# Altium schematic sheet Security panel power.SchDoc
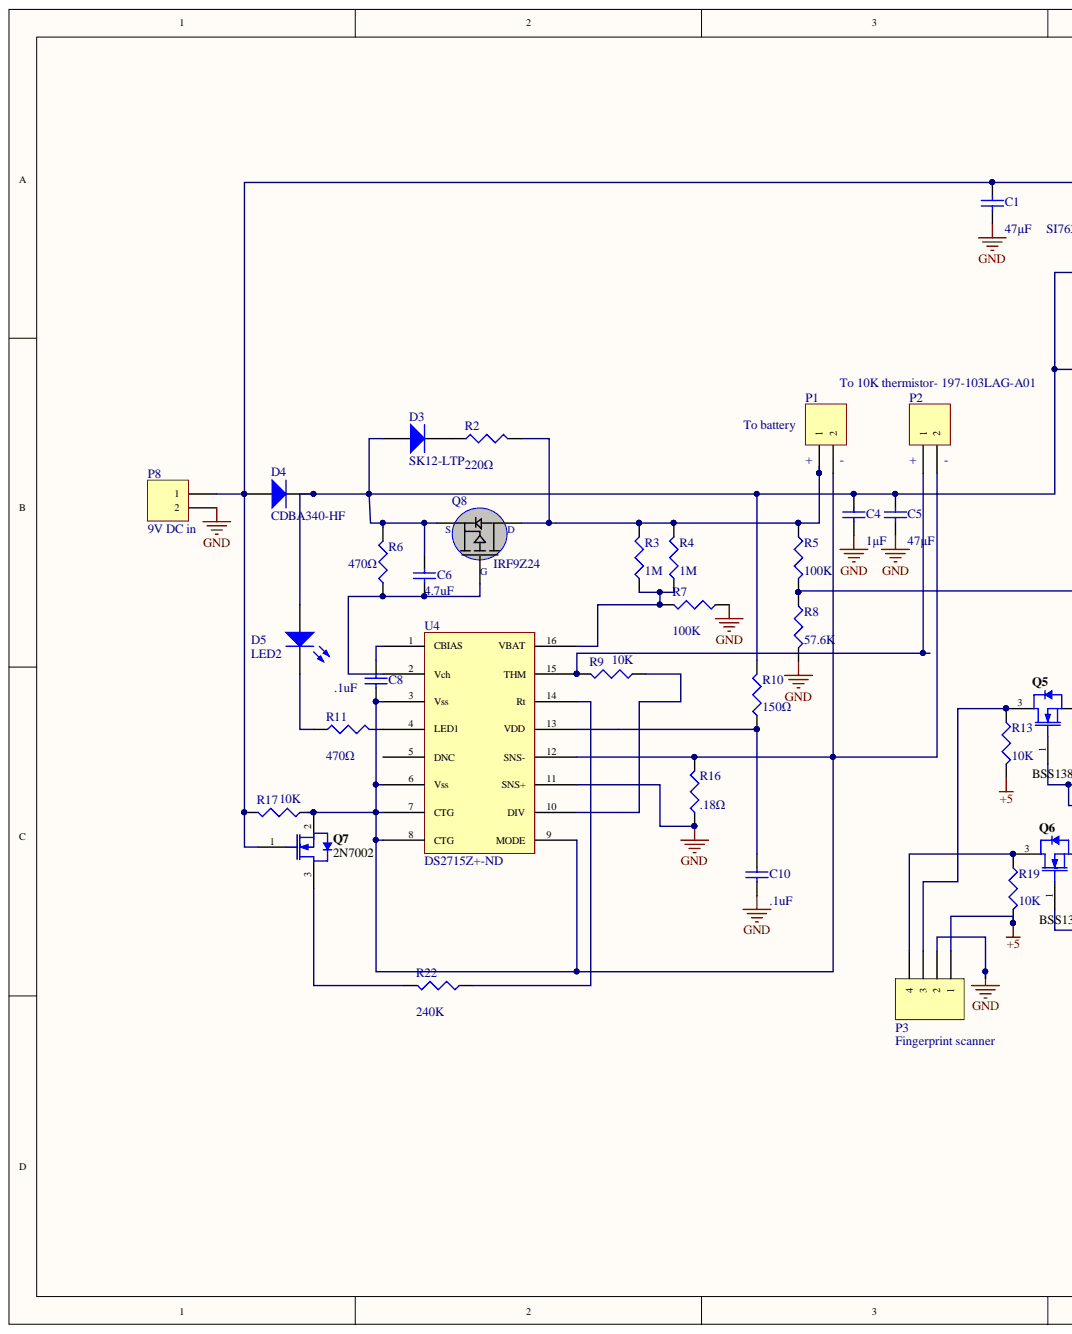
[diagram p0: Security panel power.SchDoc, left]
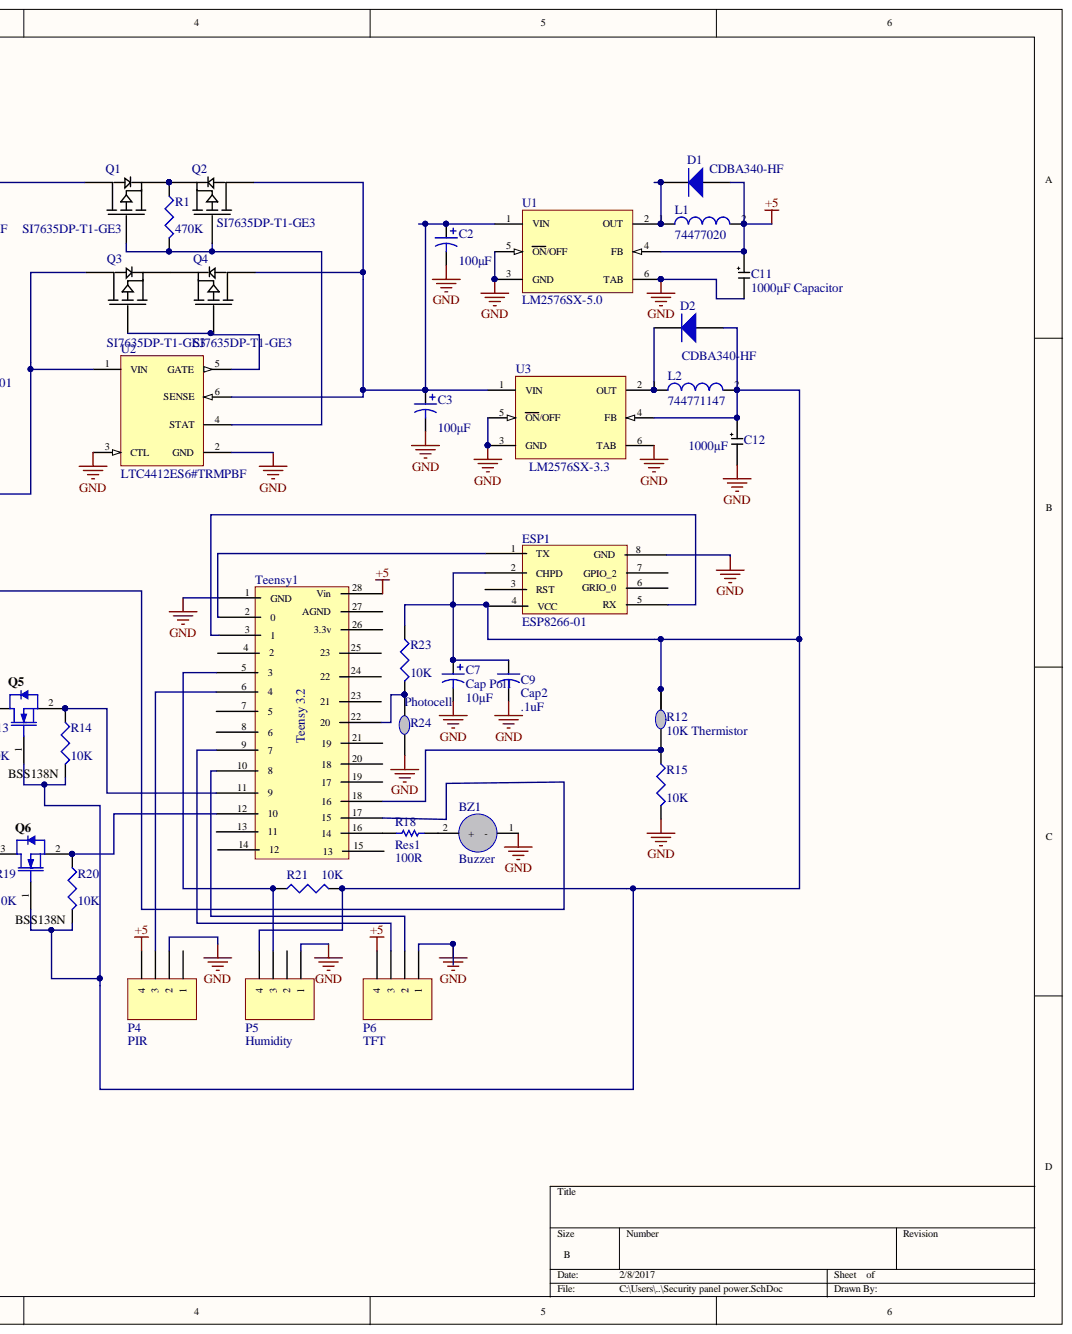
[diagram p1: Security panel power.SchDoc, right]

## Parts
BZ1  Buzzer  [Buzzer]
C1  value 47µF  [C1210]
C2  value 100μF  [100µF Capacitor]
C3  value 100μF  [100µF Capacitor]
C4  value 1µF  [1608[0603]]
C5  value 47µF  [C1210]
C6  value 4.7uF  [C2512]
C7  Cap Pol1  value 10µF  [Thermistor]
C8  value .1uF  [0402]
C9  Cap2  value .1uF  [CAPR5-4X5]
C10  value .1uF  [0402]
C11  1000µF Capacitor  value 1000µF  [1000µF Capacitor]
C12  1000µF  [1000µF Capacitor]
D1  CDBA340-HF  [SMC]
D2  CDBA340-HF  [SMC]
D3  SK12-LTP  [SMC]
D4  CDBA340-HF  [SMC]
D5  LED2  [3.2X1.6X1.1]
ESP1  ESP8266-01  [ESP8266-01]
L1  74477020  [WE-PD-XL]
L2  744771147  [WE-PD-L]
P1  To battery  [HDR1X2]
P2  To 10K thermistor- 197-103LAG-A01  [HDR1X2]
P3  Fingerprint scanner  [HDR1X4]
P4  PIR  [HDR1X4]  (value not drawn)
P5  Humidity  [HDR1X4]
P6  TFT  [HDR1X4]
P8  9V DC in  [HDR1X2]
Q1  SI7635DP-T1-GE3  [SI7635DP-T1-GE3]
Q2  SI7635DP-T1-GE3  [SI7635DP-T1-GE3]
Q3  SI7635DP-T1-GE3  [SI7635DP-T1-GE3]
Q4  SI7635DP-T1-GE3  [SI7635DP-T1-GE3]
Q5  BSS138N  [INF-PG-SOT23_N]
Q6  BSS138N  [INF-PG-SOT23_N]
Q7  2N7002  [INF-PG-SOT23_N]
Q8  IRF9Z24  [IRF9Z24NSTRLPBF]
R1  value 470K  [J1-0603]
R2  value 220Ω  [RESC6332]
R3  value 1M  [J1-0603]
R4  value 1M  [J1-0603]
R5  value 100K  [J1-0603]
R6  value 470Ω  [J1-0603]
R7  value 100K  [J1-0603]
R8  value 57.6K  [J1-0603]
R9  value 10K  [J1-0603]
R10  value 150Ω  [J1-0603]
R11  value 470Ω  [J1-0603]
R12  10K Thermistor  [Thermistor]
R13  value 10K  [J1-0603]
R14  value 10K  [J1-0603]
R15  value 10K  [J1-0603]
R16  value .18Ω  [RESC6332]
R17  value 10K  [J1-0603]
R18  Res1  value 100R  [AXIAL-0.3]
R19  value 10K  [J1-0603]
R20  value 10K  [J1-0603]
R21  value 10K  [J1-0603]
R22  value 240K  [J1-0603]
R23  value 10K  [J1-0603]
R24  Photocell  [Thermistor]
Teensy1  Teensy 3.2  [Teensy 3.2]
U1  LM2576SX-5.0  [TS5B_N]
U2  LTC4412ES6#TRMPBF  [LT-S6-6-TSOT-23_N]
U3  LM2576SX-3.3  [TS5B_N]
U4  DS2715Z+-ND  [DS2715Z+-ND]

## Nets
+5: C11.2 D1.1 L1.2 P3.1 P4.4 P6.4 R13.2 R19.2 Teensy1.28(Vin) U1.4(FB)
GND: BZ1.1 C1.1 C2.2 C3.2 C4.1 C5.1 C7.2 C9.2 C10.1 C11.1 C12.1 ESP1.8(GND) P3.2 P4.2 P5.1 P6.1 P8.2 R7.1 R8.2 R15.2 R16.1 R24.1 Teensy1.1(GND) U1.3(GND) U1.5(ON/OFF) U1.6(TAB) U2.2(GND) U2.3(CTL) U3.3(GND) U3.5(ON/OFF) U3.6(TAB) U4.11(SNS+)
NetBZ1_2: BZ1.2 R18.2
NetC1_2: C1.2 D4.1 P8.1 Q1.3 Q7.1 R17.2
NetC2_1: C2.1 C3.1 Q2.3 Q4.3 U1.1(VIN) U2.6(SENSE) U3.1(VIN)
NetC4_2: C4.2 C5.2 C6.2 D3.1 D4.2 D5.1 Q3.3 Q8.3 R6.2 R10.2 U2.1(VIN)
NetC6_1: C6.1 Q8.1 R6.1 U4.2(Vch)
NetC7_1: C7.1 C9.1 C12.2 D2.1 ESP1.2(CHPD) ESP1.4(VCC) L2.2 P5.4 Q5.1 Q6.1 R12.2 R14.2 R20.2 R21.2 R23.1 U3.4(FB)
NetC8_1: C8.1 P1.2 P2.2 Q7.2 R16.2 R17.1 U4.3(Vss) U4.6(Vss) U4.7(CTG) U4.8(CTG) U4.9(MODE) U4.12(SNS-)
NetC8_2: C8.2 U4.1(CBIAS)
NetC10_2: C10.2 R10.1 U4.13(VDD)
NetD1_2: D1.2 L1.1 U1.2(OUT)
NetD2_2: D2.2 L2.1 U3.2(OUT)
NetD3_2: D3.2 R2.1
NetD5_2: D5.2 R11.1
NetESP1_1: ESP1.1(TX) Teensy1.2
NetESP1_5: ESP1.5(RX) Teensy1.3
NetP1_1: P1.1 Q8.4 R2.2 R3.2 R4.2 R5.1
NetP2_1: P2.1 R9.2 U4.15(THM)
NetP3_3: P3.3 Q5.3 R13.1
NetP3_4: P3.4 Q6.3 R19.1
NetP4_3: P4.3 Teensy1.6
NetP5_3: P5.3 R21.1 Teensy1.5
NetP6_2: P6.2 Teensy1.10
NetP6_3: P6.3 Teensy1.9
NetQ1_1: Q1.1 Q2.1 R1.2 U2.4(STAT)
NetQ1_2: Q1.2 Q2.2 R1.1
NetQ3_1: Q3.1 Q4.1 U2.5(GATE)
NetQ3_2: Q3.2 Q4.2
NetQ5_2: Q5.2 R14.1 Teensy1.11
NetQ6_2: Q6.2 R20.1 Teensy1.12
NetQ7_3: Q7.3 R22.2
NetR3_1: R3.1 R4.1 R7.2 U4.16(VBAT)
NetR5_2: R5.2 R8.1 Teensy1.17
NetR9_1: R9.1 U4.10(DIV)
NetR11_2: R11.2 U4.4(LED1)
NetR12_1: R12.1 R15.1 Teensy1.18
NetR18_1: R18.1 Teensy1.16
NetR22_1: R22.1 U4.14(Rt)
NetR23_2: R23.2 R24.2 Teensy1.22

Not connected: ESP1 3(RST) 6(GRIO_0) 7(GPIO_2); P4 1; P5 2; Teensy1 4 7 8 13 14 15 19 20 21 23 24 25 26(3.3v) 27(AGND); U4 5(DNC)

## Library data of the parts
C1 C4-C6 C8 C10 = Cap Semi: Capacitor (Semiconductor SIM Model); Component Kind: Standard; LatestRevisionDate: 29-May-2009; LatestRevisionNote: IPC-7351 Footprint Added.; Library Name: Miscellaneous Devices.IntLib; PackageDescription: Chip Capacitor; PackageReference: C1206; Published: 8-Jun-2000; Signal Integrity: Cap Semi; Simulation: CAP; Publisher: Altium Limited
C2 C3 C7 = Cap Pol1: Polarized Capacitor (Radial); Component Kind: Standard; LatestRevisionDate: 17-Jul-2002; LatestRevisionNote: Re-released for DXP Platform.; Library Name: Miscellaneous Devices.IntLib; PackageDescription: Polarized Capacitor (Radial); 2 Leads; PackageReference: RB7.6-15; Published: 8-Jun-2000; Signal Integrity: Cap Pol; Simulation: CAP; Publisher: Altium Limited
C9 = Cap2: Capacitor; Component Kind: Standard; Library Name: Miscellaneous Devices.IntLib; PackageDescription: Capacitor; 2 Leads; PackageReference: CAPR5-4X5; Published: 23-Sep-2002; Signal Integrity: Cap; Simulation: CAP; Publisher: Altium Limited
C12 = 1000µF Capacitor: Component Kind: Standard; Library Name: my_components.SchLib; Value: 1000µF
D1-D4 = Diode: Default Diode; Code_JEDEC: DO-214-AB; Component Kind: Standard; LatestRevisionDate: 17-Jul-2002; LatestRevisionNote: Re-released for DXP Platform.; Library Name: Miscellaneous Devices.IntLib; PackageDescription: DO-214-AB/SMC; 2 C-Bend Leads; Body 7.9 x 5.9 mm, inc. leads (LxW); PackageReference: SMC; PackageVersion: Sep-1996; Published: 8-Jun-2000; Signal Integrity: Diode; Simulation: DIODE; Publisher: Altium Limited
D5 = LED2: Typical RED, GREEN, YELLOW, AMBER GaAs LED; Component Kind: Standard; LatestRevisionDate: 17-Jul-2002; LatestRevisionNote: Re-released for DXP Platform.; Library Name: Miscellaneous Devices.IntLib; PackageDescription: SM LED; 2 Flat Leads; PackageReference: 3.2X1.6X1.1; PackageVersion: Feb-2002; Published: 8-Jun-2000; Simulation: LED2; Publisher: Altium Limited
L1 = 74477020: SMD-Shielded Power Inductor WE-PD, L = 100 µH; Inductance: 100 µH; Component Kind: Standard; ComponentLink1Description: Manufacturer URL; ComponentLink1URL: http://www.we-online.com; ComponentLink2Description: Datasheet; ComponentLink2URL: http://www.we-online.de/katalog/media/pdf/74477020.pdf; DatasheetVersion: ver 5, Oct-2004; Library Name: WE-PD SMD Shielded Power Inductor.IntLib; PackageDescription: SMD Inductor, 2-Leads, Body 12.0 x 12.0 mm; PackageReference: WE-PD-XL; PartNumber: 74477020
L2 = 744771147: SMD-Shielded Power Inductor WE-PD, L = 47.0 µH; Inductance: 47.0 µH; Component Kind: Standard; ComponentLink1Description: Manufacturer URL; ComponentLink1URL: http://www.we-online.com; ComponentLink2Description: Datasheet; ComponentLink2URL: http://www.we-online.de/katalog/media/pdf/744771147.pdf; DatasheetVersion: ver 6, Jun-2005; Library Name: WE-PD SMD Shielded Power Inductor.IntLib; PackageDescription: SMD Inductor, 2-Leads, Body 12.0 x 12.0 mm; PackageReference: WE-PD-L; PartNumber: 744771147
P1 P2 P8 = Header 2: Header, 2-Pin; Component Kind: Standard; LatestRevisionDate: 17-Jul-2002; LatestRevisionNote: Re-released for DXP Platform.; Library Name: Miscellaneous Connectors.IntLib; Signal Integrity: Connector; Publisher: Altium Limited
P3-P6 = Header 4: Header, 4-Pin; Component Kind: Standard; LatestRevisionDate: 17-Jul-2002; LatestRevisionNote: Re-released for DXP Platform.; Library Name: Miscellaneous Connectors.IntLib; Signal Integrity: Connector; Publisher: Altium Limited
Q5 Q6 = BSS138N: N-Channel SIPMOS Small-Signal-Transistor, 60 V VDS, 0.23 A ID, -55 to 150 degC, PG-SOT23, Reel, Green; Manufacturer: Infineon; Green: TRUE; ID (max): 0.23 A; IDpuls (max): 0.92 A; Ptot (max): 0.36 W; QG: 1 nC; RDS (on) (max): 3,500 mOhm; RDS (on) (max) (@4.5V): 6,000 mOhm; RthJA (max): 350 K/W; VDS (max): 60 V; VGS(th) (max): 1.4 V; VGS(th) (min): 0.6 V; Component Kind: Standard; ComponentLink1Description: Manufacturer URL; ComponentLink1URL: http://www.infineon.com/; ComponentLink2Description: Datasheet; ComponentLink2URL: http://www.infineon.com/dgdl/BSS138N_Rev2.86_.pdf?folderId=db3a3043156fd573011622e10b5c1f67&fileId=db3a304330f68606013104d944d53efb; ComponentLink3Description: Package Specification; ComponentLink3URL: http://www.infineon.com/dgdl/SOT23-Package_Overview.pdf?folderId=db3a30431936bc4b0119532290053c66&fileId=db3a30431936bc4b0119533468123c85; DatasheetVersion: Rev. 2.86; Halogen-free: TRUE; Library Name: Infineon_Power_MOSFET.IntLib; Mounting Technology: Surface Mount; PackageDescription: 3-Pin Small Outline Transistor Package, 2.9 x 1.3 mm Body, 0.95 mm Pitch; PackageReference: PG-SOT23; PackageVersion: Apr-08; Packing: Reel; PartNumber: BSS138N; Supplier 1: Newark; Supplier 2: Farnell; Supplier 3: Farnell; Supplier Part Number 1: 25M7814; Supplier Part Number 2: 1156434RL; Supplier Part Number 3: 1156434
Q7 = 2N7002: N-Channel OptiMOS Small-Signal-Transistor, 60 V VDS, 0.3 A ID, -55 to 150 degC, PG-SOT23, Reel, Green; Manufacturer: Infineon; Green: TRUE; ID (max): 0.3 A; IDpuls (max): 1.2 A; Ptot (max): 0.5 W; QG: 0.4 nC; RDS (on) (max): 3,000 mOhm; RDS (on) (max) (@4.5V): 4,000 mOhm; RthJA (max): 250 K/W; VDS (max): 60 V; VGS(th) (max): 2.5 V; VGS(th) (min): 1.5 V; Component Kind: Standard; ComponentLink1Description: Manufacturer URL; ComponentLink1URL: http://www.infineon.com/; ComponentLink2Description: Datasheet; ComponentLink2URL: http://www.infineon.com/dgdl/2N7002_Rev2.4.pdf?folderId=db3a3043156fd573011622e10b5c1f67&fileId=db3a304319bc939a0119c2a9461e79e6; ComponentLink3Description: Package Specification; ComponentLink3URL: http://www.infineon.com/dgdl/SOT23-Package_Overview.pdf?folderId=db3a30431936bc4b0119532290053c66&fileId=db3a30431936bc4b0119533468123c85; DatasheetVersion: Rev. 2.4; Halogen-free: TRUE; Library Name: Infineon_Power_MOSFET.IntLib; Mounting Technology: Surface Mount; PackageDescription: 3-Pin Small Outline Transistor Package, 2.9 x 1.3 mm Body, 0.95 mm Pitch; PackageReference: PG-SOT23; PackageVersion: Apr-08; Packing: Reel; PartNumber: 2N7002; Supplier 1: Arrow; Supplier Part Number 1: 6oGnorwKIcmhtwqcFeJ1owu1VFxg26S-d6kZGECfY84
R1-R11 R13-R17 R19-R23 = Res3: Resistor; Component Kind: Standard; LatestRevisionDate: 29-May-2009; LatestRevisionNote: IPC-7351 Footprint Added.; Library Name: Miscellaneous Devices.IntLib; PackageDescription: Chip Resistor; PackageReference: J1-0603; Published: 8-Jun-2000; Signal Integrity: Res; Simulation: RESISTOR; Publisher: Altium Limited
R12 R24 = Thermistor: Component Kind: Standard; Library Name: my_components.SchLib
R18 = Res1: Resistor; Value: 100R; Component Kind: Standard; LatestRevisionDate: 17-Jul-2002; LatestRevisionNote: Re-released for DXP Platform.; Library Name: Miscellaneous Devices.IntLib; PackageDescription: Axial Device, Thru-Hole; 2 Leads; 0.3 in Pin Spacing; PackageReference: AXIAL-0.3; Published: 8-Jun-2000; Signal Integrity: Res; Simulation: RESISTOR; Publisher: Altium Limited
U1 = LM2576SX-5.0: SIMPLE SWITCHER® 3A Step-Down Voltage Regulator, 5-pin TO-263; Manufacturer: National Semiconductor; High-Reliability: Y; PackageTopMarking: NSUZXYTTE#*LM2576S*-5.0 P+; PowerWise: N; Code_JEDEC: MO-169-AB; Component Kind: Standard; ComponentLink1Description: Manufacturer URL; ComponentLink1URL: http://www.national.com/; ComponentLink2Description: LM2576 Datasheet; ComponentLink2URL: http://www.national.com/ds/LM/LM2576.pdf; ComponentLink3Description: LM2576 Web Page; ComponentLink3URL: http://www.national.com/pf/LM/LM2576.html; ComponentLink4Description: TS5B Package Page; ComponentLink4URL: http://www.national.com/packaging/folders/ts5b.html; CompType: Switcher; DatasheetVersion: Aug-2004; Library Name: National Semiconductor Switcher.IntLib; PackageDescription: TO-263, Molded, .400 x .390 x .180in, 5 Lead, .067in Pitch, Surface Mount; PackageReference: TS5B; PackageVersion: revD, Apr-2005; PartNumber: LM2576SX-5.0; PinCount: 5; RoHS: N
U2 = LTC4412ES6#TRMPBF: Low Loss PowerPath(TM) Controller, 2.5 to 28 V Vin, 6-pin SOT23 (S6-6), -40 to 85 degC, Pb-Free, Mini Tape and Reel; Manufacturer: Linear Technology; Ideal Diode: Single, External, P-Channel; Code_JEDEC: MO-193; Component Kind: Standard; ComponentLink1Description: Manufacturer URL; ComponentLink1URL: http://www.linear.com/; ComponentLink2Description: Datasheet; ComponentLink2URL: http://cds.linear.com/docs/en/datasheet/4412fa.pdf; ComponentLink3Description: Package Specification; ComponentLink3URL: http://cds.linear.com/docs/en/packaging/SOT_6_05-08-1636.pdf; Library Name: Linear Technology Power Management Power Control.IntLib; Mounting Technology: Surface Mount; PackageDescription: 6-Pin Plastic TSOT-23, Body 2.9 x 1.63 mm, Pitch 0.95 mm; PackageReference: S6-6; PackageVersion: Jun-10; Packing: Mini Tape and Reel; PartNumber: LTC4412ES6#TRMPBF; RoHS: TRUE; Supplier 1: Digi-Key; Supplier 2: Digi-Key; Supplier 3: Digi-Key; Supplier 4: Farnell; Supplier 5: Farnell; Supplier 6: Newark; Supplier Part Number 1: LTC4412ES6#TRMPBFTR-ND; Supplier Part Number 2: LTC4412ES6#TRMPBFCT-ND; Supplier Part Number 3: LTC4412ES6#TRMPBFDKR-ND; Supplier Part Number 4: 1663917RL; Supplier Part Number 5: 1663917; Supplier Part Number 6: 56M9516
U3 = LM2576SX-3.3: SIMPLE SWITCHER® 3A Step-Down Voltage Regulator, 5-pin TO-263; Manufacturer: National Semiconductor; High-Reliability: Y; PackageTopMarking: NSUZXYTTE#*LM2576S*-3.3 P+; PowerWise: N; Code_JEDEC: MO-169-AB; Component Kind: Standard; ComponentLink1Description: Manufacturer URL; ComponentLink1URL: http://www.national.com/; ComponentLink2Description: LM2576 Datasheet; ComponentLink2URL: http://www.national.com/ds/LM/LM2576.pdf; ComponentLink3Description: LM2576 Web Page; ComponentLink3URL: http://www.national.com/pf/LM/LM2576.html; ComponentLink4Description: TS5B Package Page; ComponentLink4URL: http://www.national.com/packaging/folders/ts5b.html; CompType: Switcher; DatasheetVersion: Aug-2004; Library Name: National Semiconductor Switcher.IntLib; PackageDescription: TO-263, Molded, .400 x .390 x .180in, 5 Lead, .067in Pitch, Surface Mount; PackageReference: TS5B; PackageVersion: revD, Apr-2005; PartNumber: LM2576SX-3.3; PinCount: 5; RoHS: N
U4 = DS2715Z+-ND: IC NIMH CHARGER 16-SOIC; Manufacturer: Maxim Integrated; Component Kind: Standard; Library Name: my_components.SchLib; Manufacturer Part Number: DS2715Z+
BZ1 = Buzzer: Component Kind: Standard; Library Name: my_components.SchLib
C11 = 1000µF Capacitor: Component Kind: Standard; Library Name: my_components.SchLib
ESP1 = ESP8266-01: Component Kind: Standard; Library Name: my_components.SchLib
Q1-Q4 = SI7635DP-T1-GE3: Component Kind: Standard; Library Name: my_components.SchLib
Q8 = IRF9Z24: Component Kind: Standard; Library Name: my_components.SchLib
Teensy1 = Teensy 3.2: Component Kind: Standard; Library Name: my_components.SchLib
